# Altium schematic sheet Hermes_4.0.SchDoc(Hermes_4.0)
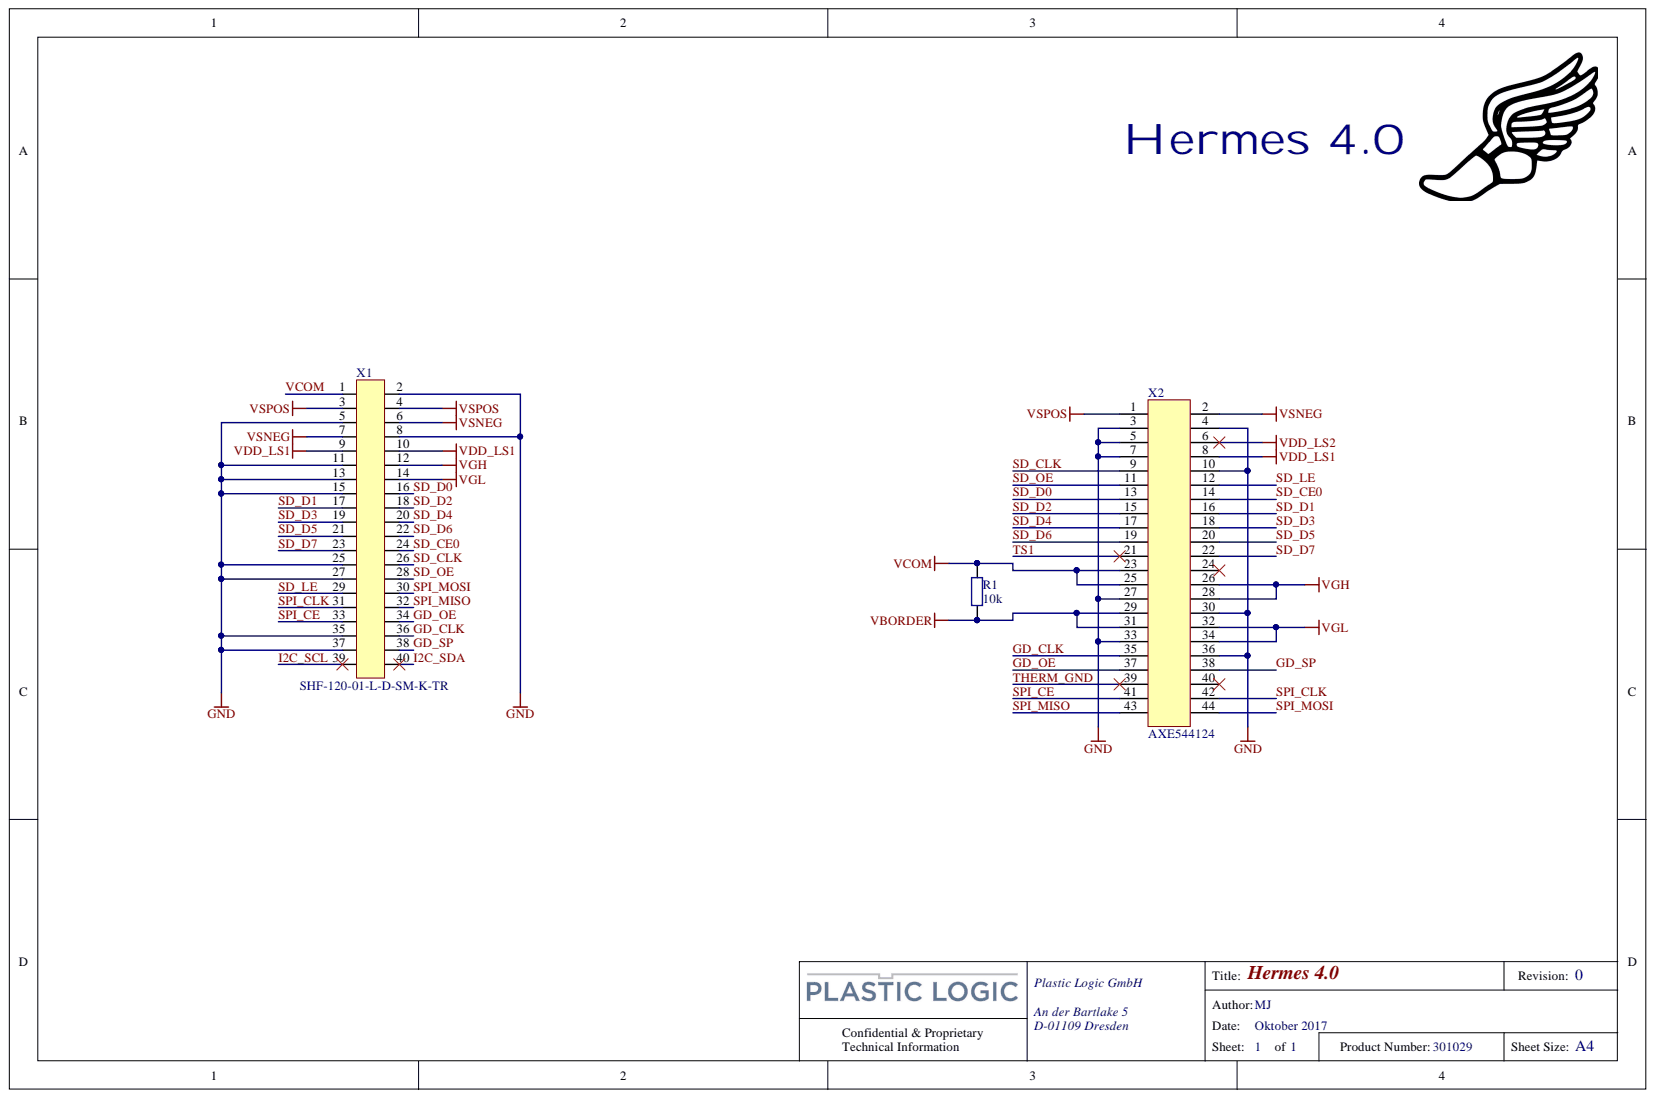
[diagram p0: Hermes_4.0.SchDoc]

## Parts
R1  10k  [R0603]
X1  SHF-120-01-L-D-SM-K-TR  [SHF-120-01-SM]
X2  AXE544124  [AXE544124]

## Nets
GND: X1.2 X1.5 X1.8 X1.11 X1.13 X1.15 X1.25 X1.27 X1.35 X1.37 X2.3 X2.4 X2.5 X2.7 X2.10 X2.27 X2.30 X2.33 X2.36
VBORDER: R1.1 X2.29 X2.31
VDD_LS1: X1.9 X1.10 X2.8
VDD_LS2: X2.6
VGH: X1.12 X2.26 X2.28
VGL: X1.14 X2.32 X2.34
VSNEG: X1.6 X1.7 X2.2
VSPOS: X1.3 X1.4 X2.1
GD_CLK: X1.36 X2.35
GD_OE: X1.34 X2.37
GD_SP: X1.38 X2.38
I2C_SCL: X1.39
I2C_SDA: X1.40
SD_CE0: X1.24 X2.14
SD_CLK: X1.26 X2.9
SD_D0: X1.16 X2.13
SD_D1: X1.17 X2.16
SD_D2: X1.18 X2.15
SD_D3: X1.19 X2.18
SD_D4: X1.20 X2.17
SD_D5: X1.21 X2.20
SD_D6: X1.22 X2.19
SD_D7: X1.23 X2.22
SD_LE: X1.29 X2.12
SD_OE: X1.28 X2.11
SPI_CE: X1.33 X2.41
SPI_CLK: X1.31 X2.42
SPI_MISO: X1.32 X2.43
SPI_MOSI: X1.30 X2.44
THERM_GND: X2.39
TS1: X2.21
VCOM: R1.2 X1.1 X2.23 X2.25

Not connected: X2 24 40

## Library data of the parts
R1 = R 0603 10k: RES SMD 10K OHM 1% 1/10W 0603; Manufacturer: Generic; Value: 10k; Tolerance: 1%; Supplier Device Package: 0603; Power: 1/10 W; Package / Case: 0603; Component Kind: Standard; Library Name: Hermes_4.0.SchLib
X1 = SHF-120-01-L-D-SM-K-TR: SAMTEC - SHF-120-01-L-D-SM-K-TR - HEADER, 1.27MM, SMT, 2X20WAY; Supplier Part Number 1: 2416490; Supplier 1: Farnell; Stock 1: 200; RoHS: YES; Pricing 1: 125=2.92, 250=2.63, 375=2.43, 500=2.32, 625=2.26, 750=2.22, 1000=2.16 (GBP); Manufacturer Part Number: SHF-120-01-L-D-SM-K-TR; Manufacturer: SAMTEC; ComponentLink2URL: http://www.farnell.com/datasheets/1927736.pdf; ComponentLink2Description: http://www.farnell.com/datasheets/1927736.pdf; ComponentLink1URL: http://www.farnell.com/cad/1788271.pdf; ComponentLink1Description: http://www.farnell.com/cad/1788271.pdf; Component Kind: Standard; Library Name: Sojus_7.4.SchLib
X2 = AXE544124: CONN SOCKET .4MM 44POS SMD; Manufacturer: Panasonic Electric Works; Pitch: 0.016" (0.40mm); Number of Positions: 44; Mated Stacking Heights: 0.8mm, 1mm; Connector Type: Socket, Center Strip Contacts; Supplier Part Number 1: 255-3137-1-ND; Supplier 1: Digi-Key; Stock 1: 1373; RoHS: RoHS Compliant; Pricing 1: 1=2.93, 10=2.432, 100=1.8013, 250=1.58024, 500=1.44104 (EUR); Packaging: Cut Tape (CT); Number of Rows: 2; Mounting Type: Surface Mount; Manufacturer Part Number: AXE544124; Height Above Board: 0.030" (0.75mm); Features: Solder Retention; Contact Finish Thickness: -; Contact Finish: Gold; ComponentLink1URL: http://www3.panasonic.biz/ac/e_download/control/connector/base-fpc/catalog/con_eng_a4s.pdf; ComponentLink1Description: http://www3.panasonic.biz/ac/e_download/control/connector/base-fpc/catalog/con_eng_a4s.pdf; Component Kind: Standard; Category: Connectors, Interconnects; Library Name: Hermes_4.0.SchLib
